# Altium schematic sheet SKC_ErrrorDisplay.SchDoc(SKC_ErrrorDisplay)
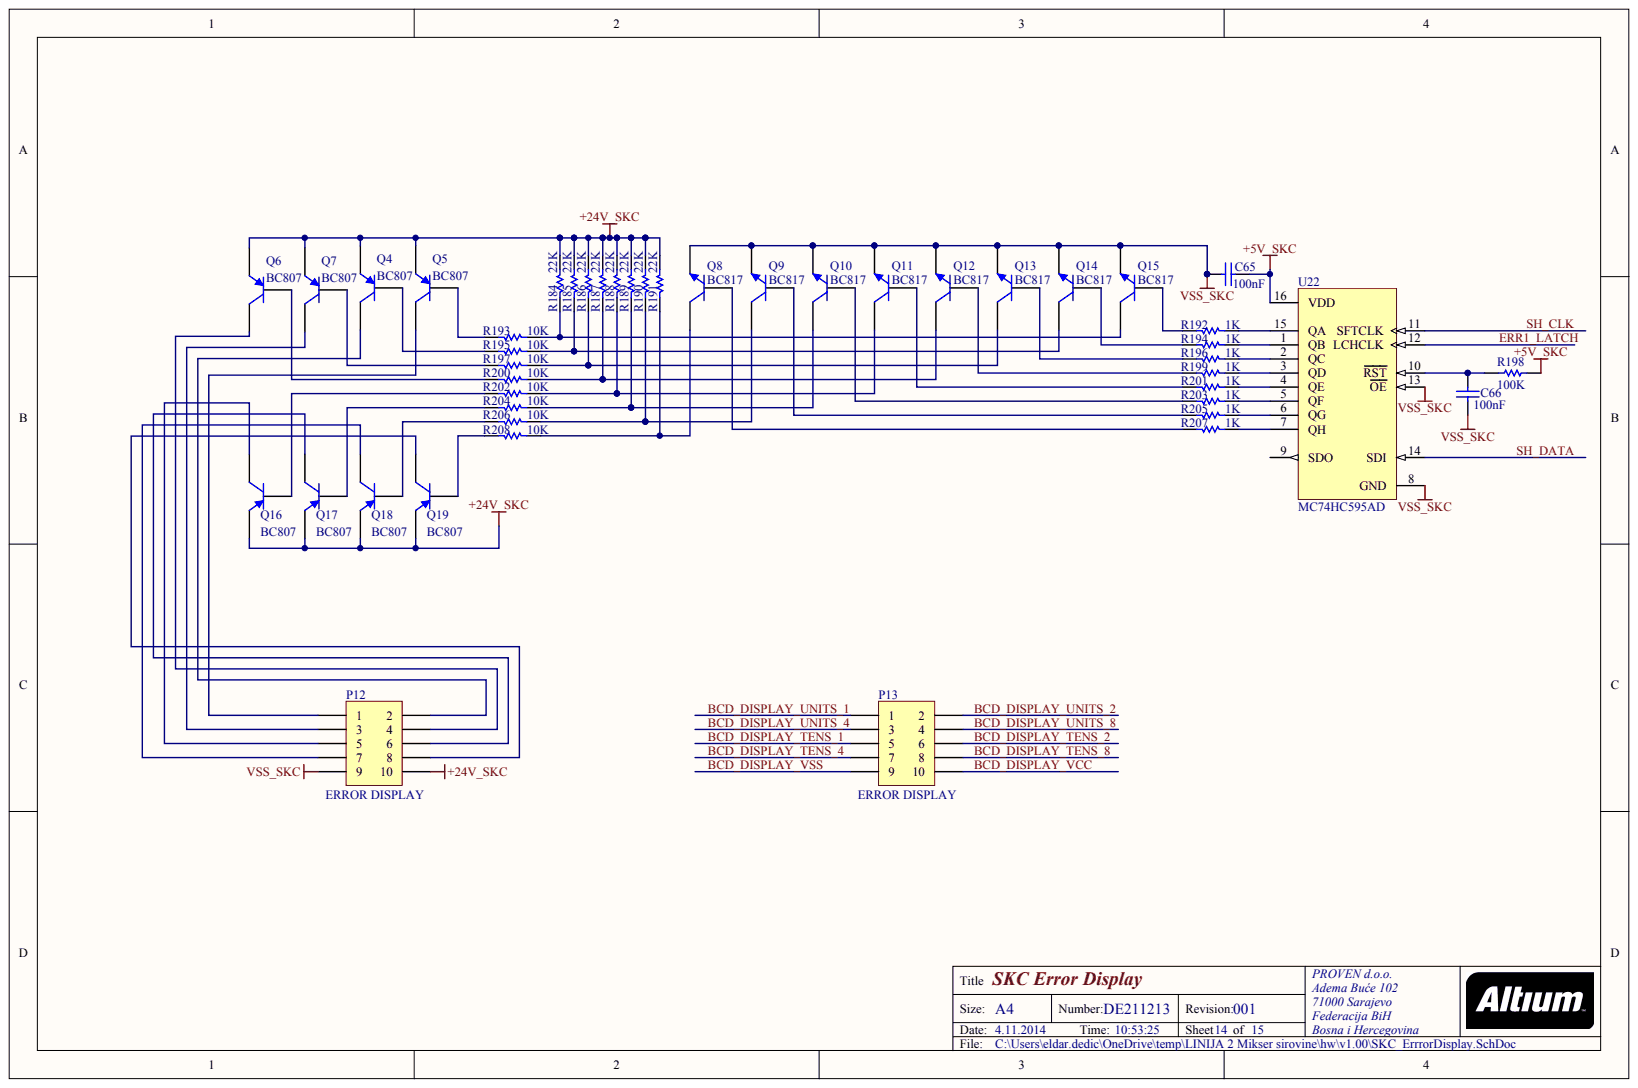
[diagram p0: SKC_ErrrorDisplay.SchDoc]

## Parts
C65  value 100nF
C66  value 100nF
P12  ERROR DISPLAY
P13  ERROR DISPLAY
Q4  BC807
Q5  BC807
Q6  BC807
Q7  BC807
Q8  BC817
Q9  BC817
Q10  BC817
Q11  BC817
Q12  BC817
Q13  BC817
Q14  BC817
Q15  BC817
Q16  BC807
Q17  BC807
Q18  BC807
Q19  BC807
R184  22K
R185  22K
R186  22K
R187  22K
R188  22K
R189  22K
R190  22K
R191  22K
R192  1K
R193  10K
R194  1K
R195  10K
R196  1K
R197  10K
R198  100K
R199  1K
R200  10K
R201  1K
R202  10K
R203  1K
R204  10K
R205  1K
R206  10K
R207  1K
R208  10K
U22  MC74HC595AD

## Nets
+5V_SKC: C65.2 R198.2 U22.16(VDD)
+24V_SKC: P12.10 Q4.2 Q5.2 Q6.2 Q7.2 Q16.2 Q17.2 Q18.2 Q19.2 R184.2 R185.2 R186.2 R187.2 R188.2 R189.2 R190.2 R191.2
VSS_SKC: C65.1 C66.1 P12.9 Q8.2 Q9.2 Q10.2 Q11.2 Q12.2 Q13.2 Q14.2 Q15.2 U22.8(GND) U22.13(OE)
BCD_DISPLAY_TENS_1: P13.5
BCD_DISPLAY_TENS_2: P13.6
BCD_DISPLAY_TENS_4: P13.7
BCD_DISPLAY_TENS_8: P13.8
BCD_DISPLAY_UNITS_1: P13.1
BCD_DISPLAY_UNITS_2: P13.2
BCD_DISPLAY_UNITS_4: P13.3
BCD_DISPLAY_UNITS_8: P13.4
BCD_DISPLAY_VCC: P13.10
BCD_DISPLAY_VSS: P13.9
ERR1_LATCH: U22.12(LCHCLK)
SH_CLK: U22.11(SFTCLK)
SH_DATA: U22.14(SDI)
NetC66_2: C66.2 R198.1 U22.10(RST)
NetP12_1: P12.1 Q5.3
NetP12_2: P12.2 Q4.3
NetP12_3: P12.3 Q7.3
NetP12_4: P12.4 Q6.3
NetP12_5: P12.5 Q16.3
NetP12_6: P12.6 Q17.3
NetP12_7: P12.7 Q18.3
NetP12_8: P12.8 Q19.3
NetQ4_1: Q4.1 R195.1
NetQ5_1: Q5.1 R193.1
NetQ6_1: Q6.1 R200.1
NetQ7_1: Q7.1 R197.1
NetQ8_1: Q8.1 R207.1
NetQ8_3: Q8.3 R191.1 R208.2
NetQ9_1: Q9.1 R205.1
NetQ9_3: Q9.3 R190.1 R206.2
NetQ10_1: Q10.1 R203.1
NetQ10_3: Q10.3 R189.1 R204.2
NetQ11_1: Q11.1 R201.1
NetQ11_3: Q11.3 R188.1 R202.2
NetQ12_1: Q12.1 R199.1
NetQ12_3: Q12.3 R187.1 R200.2
NetQ13_1: Q13.1 R196.1
NetQ13_3: Q13.3 R186.1 R197.2
NetQ14_1: Q14.1 R194.1
NetQ14_3: Q14.3 R185.1 R195.2
NetQ15_1: Q15.1 R192.1
NetQ15_3: Q15.3 R184.1 R193.2
NetQ16_1: Q16.1 R202.1
NetQ17_1: Q17.1 R204.1
NetQ18_1: Q18.1 R206.1
NetQ19_1: Q19.1 R208.1
NetR192_2: R192.2 U22.15(QA)
NetR194_2: R194.2 U22.1(QB)
NetR196_2: R196.2 U22.2(QC)
NetR199_2: R199.2 U22.3(QD)
NetR201_2: R201.2 U22.4(QE)
NetR203_2: R203.2 U22.5(QF)
NetR205_2: R205.2 U22.6(QG)
NetR207_2: R207.2 U22.7(QH)

Not connected: U22 9(SDO)

## Library data of the parts
R184-R208 = (no symbol name): Note: If flow soldering, adjust pad width of PCB Footprint to 0.7 - 0.8 times the width of the resistor.; Published: 17-Dec-2003; LatestRevisionDate: 19-Mar-2004; LatestRevisionNote: Silkscreen size reduced.; PackageDocument: Mar-2003; ComponentLink1Description: Manufacturer Link; ComponentLink1URL: http://www.panasonic.com/industrial/components/resistive_products.htm; ComponentLink2Description: Datasheet; ComponentLink2URL: http://www.panasonic.com/industrial/components/pdf/AOA0000CE8.pdf; Publisher: Altium Limited
  R184-R197 R199-R208: PackageReference: ERA-0805; Code_IPC: 2012 [0805]
  R198: PackageReference: ERA-0603; Code_IPC: 1608 [0603]
C65 C66 P12 P13 Q4-Q19 U22 = (no symbol name): Publisher: Altium Limited
  C65 C66: Published: 17-Jul-2002; LatestRevisionDate: 19-Mar-2004; LatestRevisionNote: Silkscreen size reduced.; DatasheetDocument: Mar-2001; PackageDocument: Mar-2001; PackageReference: 1206; Code_JEITA: 1206; Code_IPC: 3216 [1206]; ComponentLink1Description: Manufacturer Link; ComponentLink1URL: http://www.kemet.com/; ComponentLink2Description: Datasheet; ComponentLink2URL: http://www.kemet.com/kemet/web/homepage/kechome.nsf/vapubfilesname/F3102TA.pdf/$file/F3102TA.pdf
  P12 P13: LatestRevisionDate: 17-Jul-2002; LatestRevisionNote: Re-released for DXP Platform.
  Q4-Q7 Q16-Q19: Published: 17-Sep-2003; LatestRevisionDate: 12-Dec-2006; LatestRevisionNote: IPC-7351 Footprint Added.; DatasheetVersion: 8-Apr-1999; PackageVersion: May-1999; PackageReference: SOT23; PackageDescription: 3-Pin SOT-23 Package 0.95 mm Pitch, 2.3 mm Lead Span; ComponentLink1Description: Manufacturer Link; ComponentLink1URL: http://www.semiconductors.philips.com/; ComponentLink2Description: Datasheet; ComponentLink2URL: http://www.semiconductors.philips.com/acrobat/datasheets/BC807_BC807W_BC327_5.pdf
  Q8-Q15: Published: 17-Sep-2003; LatestRevisionDate: 12-Dec-2006; LatestRevisionNote: IPC-7351 Footprint Added.; DatasheetVersion: Jun-1999; PackageVersion: May-1999; PackageReference: SOT23; PackageDescription: 3-Pin SOT-23 Package 0.95 mm Pitch, 2.3 mm Lead Span; ComponentLink1Description: Manufacturer Link; ComponentLink1URL: http://www.semiconductors.philips.com/; ComponentLink2Description: Datasheet; ComponentLink2URL: http://www.semiconductors.philips.com/acrobat/datasheets/BC817_BC817W_BC337_5.pdf
  U22: Published: 15-Apr-2002; LatestRevisionDate: 13-Apr-2006; LatestRevisionNote: IPC-7351 Footprint Added.; DatasheetVersion: Mar-2000; PackageVersion: Oct-1999; PackageReference: 751B-05; PackageDescription: 16-Pin Small Outline Integrated Circuit 1.27 mm Pitch; Code_JEDEC: MS-012-AC; Code_IPC: SOIC127P600-16; ComponentLink1Description: Manufacturer Link; ComponentLink1URL: http://www.onsemi.com/; ComponentLink2Description: Datasheet; ComponentLink2URL: http://www.onsemi.com/pub/Collateral/MC74HC595A-D.PDF
